# Altium schematic sheet Page1.SchDoc(Page1)
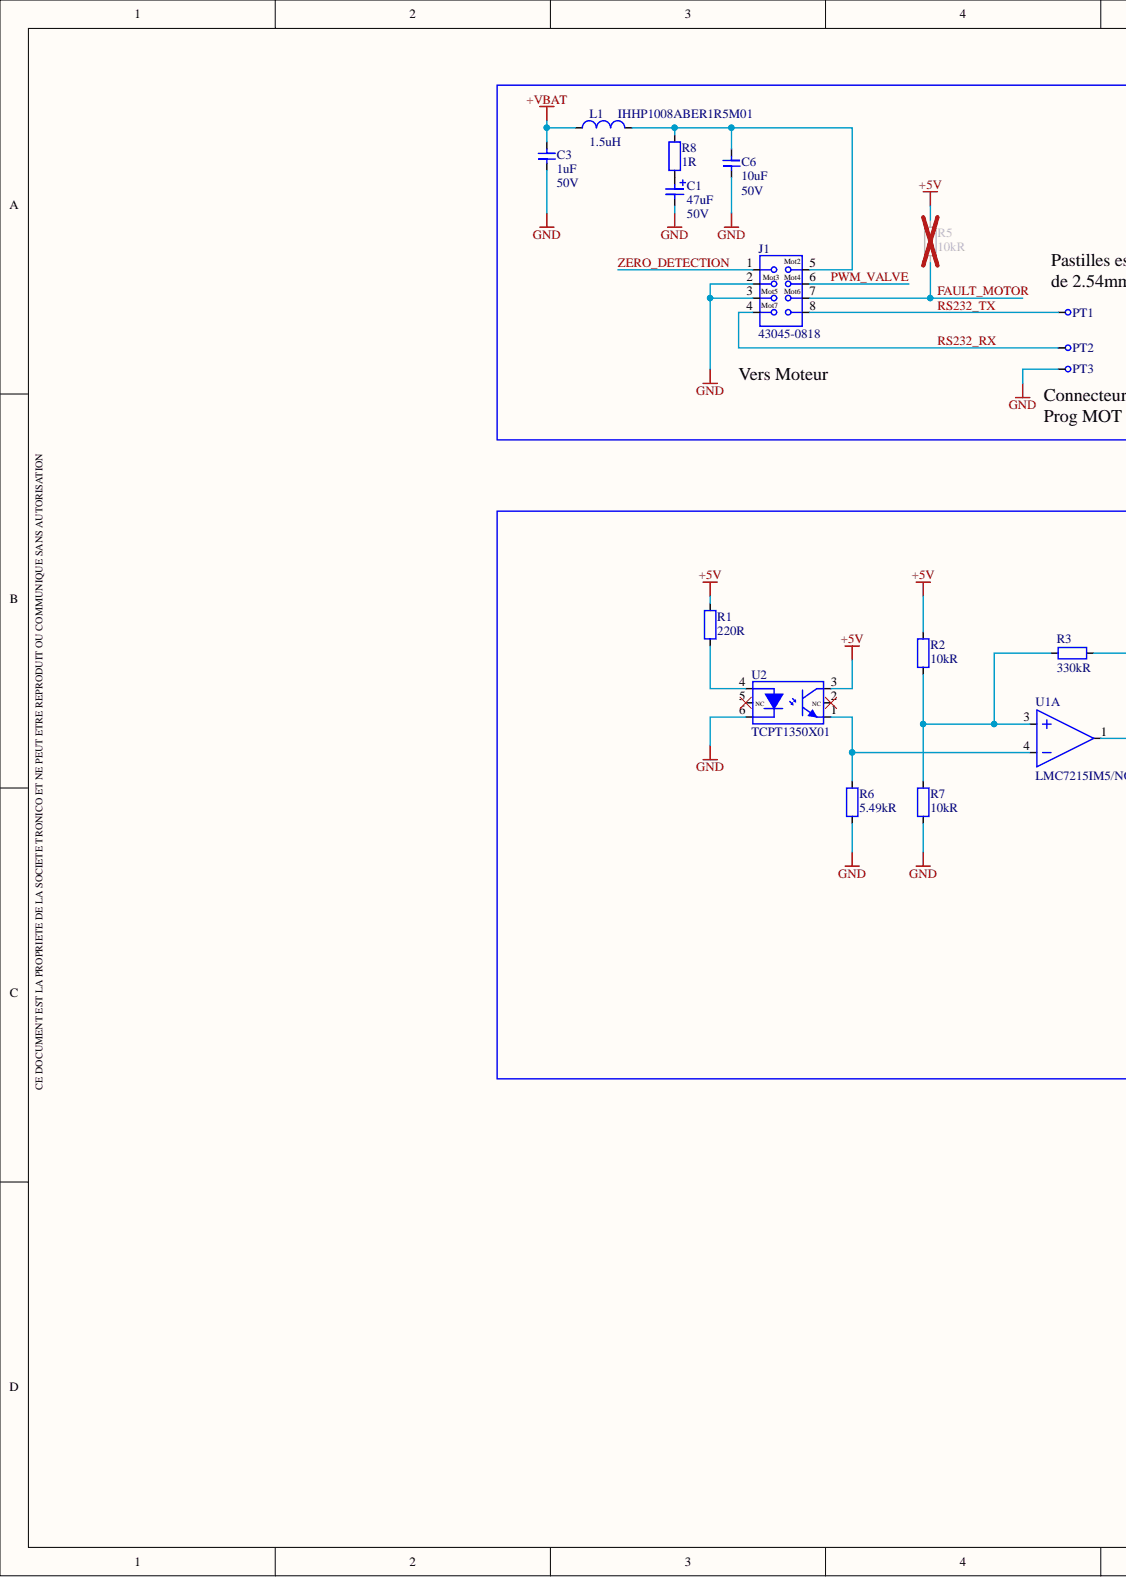
[diagram p0: Page1.SchDoc, left]
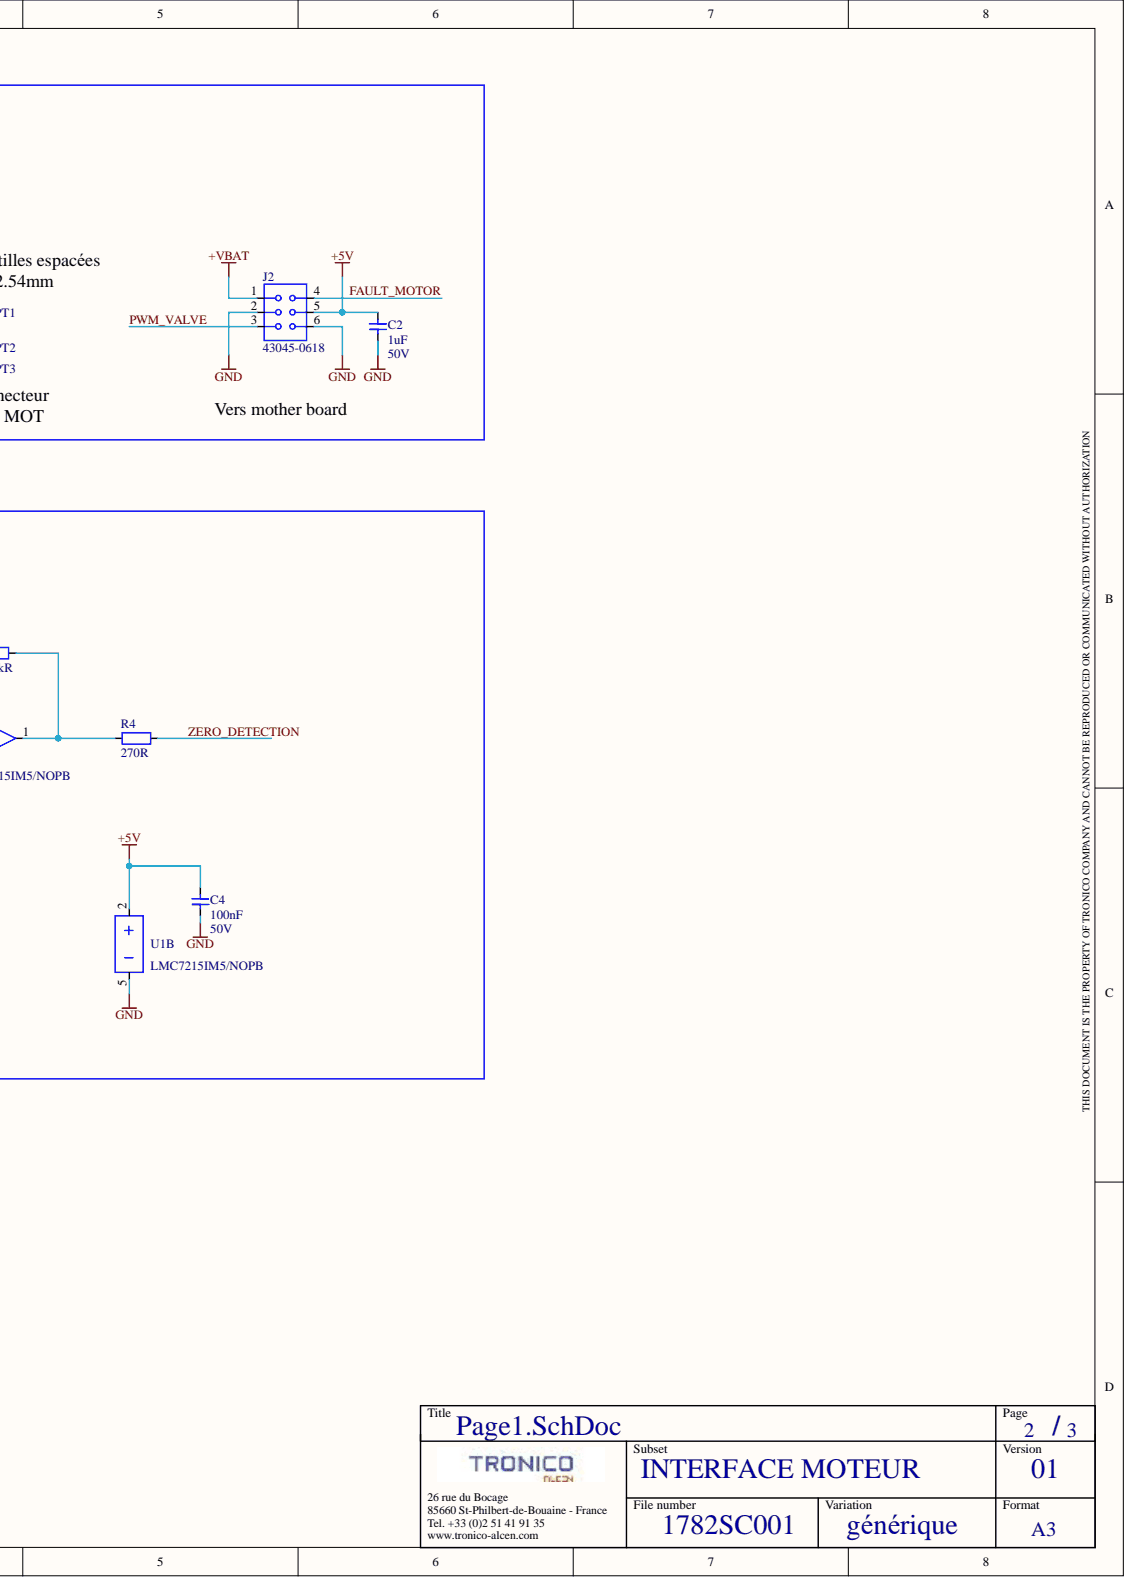
[diagram p1: Page1.SchDoc, right]

## Parts
C1  47uF  Parameter 1: 50V  [CAPE_D_B]
C2  1uF  Parameter 1: 50V  [CAPC_0603X080_T]
C3  1uF  Parameter 1: 50V  [CAPC_0603X080_T]
C4  100nF  Parameter 1: 50V  [CAPC_0603X094_T]
C6  10uF  Parameter 1: 50V  [CAPC_1206X160_T]
J1  43045-0818  [CNT_MOLEX_43045-0818_B]
J2  43045-0618  [CNT_MOLEX_43045-0618_B]
L1  IHHP1008ABER1R5M01  CHAMP5: 1.5uH  [IND_1008X120_B]
PT1  PTC3  [BP_0.8]  (value not drawn)  (no BOM)
PT2  PTC3  [BP_0.8]  (value not drawn)  (no BOM)
PT3  PTC3  [BP_0.8]  (value not drawn)  (no BOM)
R1  220R  [RESC_0603X045_T]
R2  10kR  [RESC_0603X045_T]
R3  330kR  [RESC_0603X045_T]
R4  270R  [RESC_0805X045_T]
R5  10kR  [RESC_0603X045_T]
R6  5.49kR  [RESC_0603X045_T]
R7  10kR  [RESC_0603X045_T]
R8  1R  [RESC_2512X060_T]
U1  LMC7215IM5/NOPB  [SOT23-5_SOT25_TI]  (parts A,B)
U2  TCPT1350X01  [TCPT1350X01]

## Nets
+5V: C2.2 C4.2 J2.5 R1.1 R2.1 R5.1 U1.2 U2.3
+VBAT: C3.2 J2.1 L1.1
GND: C1.2 C2.1 C3.1 C4.1 C6.1 J1.2 J1.3 J2.2 J2.6 PT3.1 R6.2 R7.2 U1.5 U2.6
FAULT_MOTOR: J1.7 J2.4 R5.2
PWM_VALVE: J1.6 J2.3
RS232_RX: J1.4 PT2.1
RS232_TX: J1.8 PT1.1
ZERO_DETECTION: J1.1 R4.1
NetC1_1: C1.1 R8.2
NetC6_2: C6.2 J1.5 L1.2 R8.1
NetR1_2: R1.2 U2.4
NetR2_2: R2.2 R3.2 R7.1 U1.3
NetR3_1: R3.1 R4.2 U1.1
NetR6_1: R6.1 U1.4 U2.1

Not connected: U2 2(NC) 5(NC)

## Library data of the parts
C1 = 21U00041: COND_ALUM CMS D:6.3mm 47uF 50V 20% 680mR; REV_NOTE: Migration vers t_bt; REV_AUTHOR: lclavier; REF_FAB: EEEFT1H470AP; QUALIF: None; LEVEL: 1; FAMILLE: CAPACITIFS; FAB: PANASONIC; Database Table Name: v_bt_all; CHAMP9: -55°C/+105°C; SS_FAMILLE: COND_ALUM; Simulation: CAP; Signal Integrity: Cap Pol; REV_DATE: 14/04/2020 16:15:27; ID_RnD: 21U00041; HelpURL: http://bt_rnd/ds/02_capacitif/Panasonic/EEEFT1H470AP.pdf; ComponentLink1URL: https://industrial.panasonic.com/ww/products/capacitors/aluminum-capacitors/aluminum-cap-smd/ft-v-high-temp-reflow/EEEFT1H470AP; Component Kind: Standard; CHAMP8: 680mR; CHAMP7: 20%; CHAMP6: 50V; CHAMP5: 47uF; CHAMP3: D:6.3mm; CHAMP1: CMS; ACTIF: ACTIF; Library Name: SVNDatabase_BaseTechnique.SVNDbLib
C2 C3 = 23U00347: COND_CERAM CMS 0603 X5R 1uF 50V 10%; REV_NOTE: Maj Champs Techniques; REV_AUTHOR: nlelievre; REF_FAB: CGA3E3X5R1H105K080AB; LEVEL: 1; FAMILLE: CAPACITIFS; FAB: TDK; Database Table Name: v_bt_all; CHAMP9: -55°C/+85°C; CHAMP2: 0603; SS_FAMILLE: COND_CERAM; Simulation: CAP; Signal Integrity: Cap; REV_DATE: 16/10/2019 13:35:17; Library Name: SVNDatabase_BaseTechnique.SVNDbLib; ID_RnD: 23U00347; HelpURL: http://bt_rnd/ds/02_capacitif/TDK/mlccspec_automotive_general_midvoltage_en.pdf; ComponentLink2Description: Manufacturer Website; ComponentLink1Description: Manufacturer Datasheet; Component Kind: Standard; CHAMP7: 10%; CHAMP6: 50V; CHAMP5: 1uF; CHAMP3: X5R; CHAMP1: CMS; ACTIF: ACTIF
C4 = 23U00036: COND_CERAM CMS 0603 X7R 100nF 50V 10%; REV_NOTE: Maj Champs Techniques; REV_AUTHOR: nlelievre; REF_FAB: 06035C104KAT2A; LEVEL: 1; FAMILLE: CAPACITIFS; FAB: AVX; Database Table Name: v_bt_all; CHAMP9: -55°C/+125°C; CHAMP2: 0603; SS_FAMILLE: COND_CERAM; Simulation: CAP; Signal Integrity: Cap; REV_DATE: 16/10/2019 13:20:57; Library Name: SVNDatabase_BaseTechnique.SVNDbLib; ID_RnD: 23U00036; HelpURL: http://Bt_rnd/ds/02_capacitif/AVX/AVX_cx7r.pdf; ComponentLink2Description: Manufacturer Website; ComponentLink1Description: Manufacturer Datasheet; Component Kind: Standard; CHAMP7: 10%; CHAMP6: 50V; CHAMP5: 100nF; CHAMP3: X7R; CHAMP1: CMS; ACTIF: ACTIF
C6 = 23U00488: COND_CERAM CMS 1206 X5R 10uF 50V 10%; REV_NOTE: Migration vers t_bt; REV_AUTHOR: lclavier; REF_FAB: GRM31CR61H106KA12L; LEVEL: 1; FAMILLE: CAPACITIFS; FAB: MURATA; Database Table Name: v_bt_all; CHAMP9: -55°C/+85°C; CHAMP2: 1206; SS_FAMILLE: COND_CERAM; Simulation: CAP; Signal Integrity: Cap; REV_DATE: 14/04/2020 16:23:14; ID_RnD: 23U00488; HelpURL: http://bt_rnd/ds/02_capacitif/murata/GRM31CR61H106KA12L.pdf; ComponentLink1URL: https://www.murata.com/en-us/products/productdetail?partno=GRM31CR61H106KA12%23; Component Kind: Standard; CHAMP7: 10%; CHAMP6: 50V; CHAMP5: 10uF; CHAMP3: X5R; CHAMP1: CMS; ACTIF: ACTIF; Library Name: SVNDatabase_BaseTechnique.SVNDbLib
J1 = A7U00233: CONNECT MICRO-FIT CMS 2x4PTS EMBASE RECT MALE DROIT; REV_NOTE: MaJ Champs Techniques; REV_AUTHOR: llannuzel; LEVEL: 1; FAMILLE: CONNECTIQUE; FAB: MOLEX; Database Table Name: v_bt_all; CHAMP9: -40°C/+105°C; SS_FAMILLE: CONNECT; REV_DATE: 21/06/2019 09:53:44; REF_FAB: 43045-0818; Library Name: SVNDatabase_BaseTechnique.SVNDbLib; ID_RnD: A7U00233; HelpURL: http://bt_rnd/ds/10_connectique/MOLEX/43045_Series.pdf; ComponentLink2URL: https://www.molex.com/molex/products/datasheet.jsp?part=active/0430450818_PCB_HEADERS.xml; ComponentLink2Description: Manufacturer Website; ComponentLink1URL: https://www.molex.com/pdm_docs/sd/430450818_sd.pdf; ComponentLink1Description: Manufacturer Datasheet; Component Kind: Standard; CHAMP8: DROIT; CHAMP7: MALE; CHAMP6: RECT; CHAMP5: EMBASE; CHAMP4: 2x4PTS; CHAMP3: CMS; CHAMP1: MICRO-FIT; ACTIF: ACTIF
J2 = A7U00234: CONNECT MICRO-FIT CMS 2x3PTS EMBASE RECT MALE DROIT; REV_NOTE: MaJ Champs Techniques; REV_AUTHOR: llannuzel; LEVEL: 1; FAMILLE: CONNECTIQUE; FAB: MOLEX; Database Table Name: v_bt_all; CHAMP9: -40°C/+105°C; SS_FAMILLE: CONNECT; REV_DATE: 21/06/2019 09:53:44; REF_FAB: 43045-0618; Library Name: SVNDatabase_BaseTechnique.SVNDbLib; ID_RnD: A7U00234; HelpURL: http://bt_rnd/ds/10_connectique/MOLEX/43045_Series.pdf; ComponentLink2URL: https://www.molex.com/pdm_docs/sd/430450618_sd.pdf; ComponentLink2Description: Manufacturer Website; ComponentLink1URL: https://www.molex.com/molex/products/datasheet.jsp?part=active/0430450618_PCB_HEADERS.xml; ComponentLink1Description: Manufacturer Datasheet; Component Kind: Standard; CHAMP8: DROIT; CHAMP7: MALE; CHAMP6: RECT; CHAMP5: EMBASE; CHAMP4: 2x3PTS; CHAMP3: CMS; CHAMP1: MICRO-FIT; ACTIF: ACTIF
L1 = 42U00104: SELF CMS 2.5x2mm  1.5uH 20% 3.1A; REV_NOTE: Maj Footprint Ref & Footprint Path; REV_AUTHOR: jcaille; LEVEL: 1; FAMILLE: INDUCTIFS; FAB: VISHAY; Database Table Name: v_bt_all; CHAMP9: -55°C/+125°C; SS_FAMILLE: SELF; Simulation: INDUCTOR; Signal Integrity: Inductor; REV_DATE: 10/02/2020 15:29:38; REF_FAB: IHHP1008ABER1R5M01; ID_RnD: 42U00104; HelpURL: http://bt_rnd/ds/04_inductif/vishay/IHHP_1008_AB_01.pdf; ComponentLink2Description: Manufacturer Website; ComponentLink1Description: Manufacturer Datasheet; Component Kind: Standard; CHAMP7: 3.1A; CHAMP6: 20%; CHAMP2: 2.5x2mm; CHAMP1: CMS; ACTIF: ACTIF; Library Name: SVNDatabase_BaseTechnique.SVNDbLib
PT1-PT3 = H6U00003: POINT_TEST; REV_NOTE: Migration vers t_bt; REV_AUTHOR: jrenvoise; LEVEL: 1; FAMILLE: DIVERS; FAB: TRONICO; Database Table Name: v_bt_all; SS_FAMILLE: POINT_TEST; REV_DATE: 24/04/2017 12:22:28; REF_FAB: PTC3; ID_RnD: H6U00003; ACTIF: ACTIF; Library Name: SVNDatabase_BaseTechnique.SVNDbLib
R1 = 11U00106: REST CMS 0603 100PPM  220R 1/10W 1%  -55°C/+155°C; Valide / Non Valide: OK; REV_NOTE: MaJ Champs Techniques; REV_AUTHOR: llannuzel; REF_FAB: RC0603FR-07220RL; LEVEL: 1; FAMILLE: RESISTIFS; FAB: YAGEO; Database Table Name: v_bt_all; CHAMP2: 0603; SS_FAMILLE: REST; Simulation: RESISTOR; Signal Integrity: Res; REV_DATE: 11/02/2019 09:50:59; Library Name: SVNDatabase_BaseTechnique.SVNDbLib; ID_RnD: 11U00106; HelpURL: http://bt_rnd/ds/01_resistif/yageo/RC-L_Series.pdf; ComponentLink2Description: Manufacturer Website; ComponentLink1Description: Manufacturer Datasheet; Component Kind: Standard; CHAMP9: -55°C/+155°C; CHAMP7: 1%; CHAMP6: 1/10W; CHAMP5: 220R; CHAMP3: 100PPM; CHAMP1: CMS; ACTIF: ACTIF
R2 R5 R7 = 11U00108: REST CMS 0603 100PPM 10kR 1/10W 1% -55°C/+155°C; Valide / Non Valide: OK; REV_NOTE: MaJ description; REV_AUTHOR: jrenvoise; REF_FAB: RC0603FR-0710KL; LEVEL: 1; FAMILLE: RESISTIFS; FAB: YAGEO; Database Table Name: v_bt_all; CHAMP2: 0603; SS_FAMILLE: REST; Simulation: RESISTOR; Signal Integrity: Res; REV_DATE: 12/09/2018 10:54:26; ID_RnD: 11U00108; HelpURL: http://bt_rnd/ds/01_resistif/yageo/RC-L_Series.pdf; ComponentLink2Description: Manufacturer Website; ComponentLink1Description: Manufacturer Datasheet; Component Kind: Standard; CHAMP9: -55°C/+155°C; CHAMP7: 1%; CHAMP6: 1/10W; CHAMP5: 10kR; CHAMP3: 100PPM; CHAMP1: CMS; ACTIF: ACTIF; Library Name: SVNDatabase_BaseTechnique.SVNDbLib
R3 = 11U00053: REST CMS 0603 100PPM 330kR 1/10W 1% -55°C/+155°C; Valide / Non Valide: OK; REV_NOTE: MaJ lien vers datasheet; REV_AUTHOR: jrenvoise; REF_FAB: CRCW0603330KFKEA; LEVEL: 1; FAMILLE: RESISTIFS; FAB: VISHAY; Database Table Name: v_bt_all; CHAMP2: 0603; SS_FAMILLE: REST; Simulation: RESISTOR; Signal Integrity: Res; REV_DATE: 10/09/2018 16:59:01; ID_RnD: 11U00053; HelpURL: http://bt_rnd/ds/01_resistif/vishay/Vishay_D-CRCWE3.pdf; ComponentLink2Description: Manufacturer Website; ComponentLink1Description: Manufacturer Datasheet; Component Kind: Standard; CHAMP9: -55°C/+155°C; CHAMP7: 1%; CHAMP6: 1/10W; CHAMP5: 330kR; CHAMP3: 100PPM; CHAMP1: CMS; ACTIF: ACTIF; Library Name: SVNDatabase_BaseTechnique.SVNDbLib
R4 = 11U00279: REST CMS 0805 100PPM 270R 1/8W 1%  -55°C/+155°C; Valide / Non Valide: OK; REV_NOTE: MaJ description; REV_AUTHOR: jrenvoise; REF_FAB: RC0805FR-07270RL; LEVEL: 1; FAMILLE: RESISTIFS; FAB: YAGEO; Database Table Name: v_bt_all; CHAMP2: 0805; SS_FAMILLE: REST; Simulation: RESISTOR; Signal Integrity: Res; REV_DATE: 12/09/2018 11:21:20; ID_RnD: 11U00279; HelpURL: http://bt_rnd/ds/01_resistif/yageo/RC-L_Series.pdf; ComponentLink2Description: Manufacturer Website; ComponentLink1Description: Manufacturer Datasheet; Component Kind: Standard; CHAMP9: -55°C/+155°C; CHAMP7: 1%; CHAMP6: 1/8W; CHAMP5: 270R; CHAMP3: 100PPM; CHAMP1: CMS; ACTIF: ACTIF; Library Name: SVNDatabase_BaseTechnique.SVNDbLib
R6 = 11U00688: REST CMS 0603 100PPM 5.49kR 1/10W 1% -55°C/+155°C; Valide / Non Valide: OK; REV_NOTE: MaJ description; REV_AUTHOR: jrenvoise; REF_FAB: RC0603FR-075K49L; LEVEL: 1; FAMILLE: RESISTIFS; FAB: YAGEO; Database Table Name: v_bt_all; CHAMP2: 0603; SS_FAMILLE: REST; Simulation: RESISTOR; Signal Integrity: Res; REV_DATE: 12/09/2018 11:17:00; ID_RnD: 11U00688; HelpURL: http://bt_rnd/ds/01_resistif/yageo/RC-L_Series.pdf; ComponentLink2Description: Manufacturer Website; ComponentLink1Description: Manufacturer Datasheet; Component Kind: Standard; CHAMP9: -55°C/+155°C; CHAMP7: 1%; CHAMP6: 1/10W; CHAMP5: 5.49kR; CHAMP3: 100PPM; CHAMP1: CMS; ACTIF: ACTIF; Library Name: SVNDatabase_BaseTechnique.SVNDbLib
R8 = 11U01009: REST CMS 2512 100PPM 1R 1.5W 1% 500V; Valide / Non Valide: OK; REV_NOTE: MaJ Champs URL; REV_AUTHOR: lclavier; REF_FAB: CRCW25121R00FKEGHP; QUALIF: None; LEVEL: 1; FAMILLE: RESISTIFS; FAB: VISHAY; Database Table Name: v_bt_all; CHAMP9: -55°C/+155°C; CHAMP2: 2512; SS_FAMILLE: REST; Simulation: RESISTOR; Signal Integrity: Res; REV_DATE: 16/04/2020 16:46:14; ID_RnD: 11U01009; HelpURL: http://bt_rnd/ds/01_resistif/Vishay/CRCW25121R00FKEGHP.pdf; ComponentLink1URL: https://octopart.com/crcw25121r00fkeghp-vishay-44382594?r=sp; Component Kind: Standard; CHAMP8: 500V; CHAMP7: 1%; CHAMP6: 1.5W; CHAMP5: 1R; CHAMP3: 100PPM; CHAMP1: CMS; ACTIF: ACTIF; Library Name: SVNDatabase_BaseTechnique.SVNDbLib
U1 = 61U00045: CI_AOP_COMPAR CMS SOT23-5  Single COMP +2.7V/+5V; REV_NOTE: MaJ Champs Techniques; REV_AUTHOR: llannuzel; LEVEL: 1; FAMILLE: CIRCUITS_INTEGRES; FAB: TEXAS INSTRUMENTS; Database Table Name: v_bt_all; CHAMP10: -65°C/+150°C; CHAMP9: -40°C/+85°C; CHAMP8: R TO R; SS_FAMILLE: CI_AOP_COMPAR; REV_DATE: 09/05/2019 10:36:41; REF_FAB: LMC7215IM5/NOPB; Library Name: SVNDatabase_BaseTechnique.SVNDbLib; ID_RnD: 61U00045; HelpURL: http://bt_rnd/ds/06_ci/TI/LMC7215_LMC7225.pdf; ComponentLink2Description: Manufacturer Website; ComponentLink1Description: Manufacturer Datasheet; Component Kind: Standard; CHAMP7: +2.7V/+5V; CHAMP6: COMP; CHAMP5: SINGLE; CHAMP2: SOT23-5; CHAMP1: CMS; ACTIF: ACTIF
U2 = ECU00004: CAPTEUR_OPTO CMS 5.5x4x4 PHOTOTRANSISTOR +1.0V/+1.4V NPN; Valide: OK; REV_NOTE: MaJ Champs Techniques; REV_AUTHOR: lclavier; LEVEL: 1; FAMILLE: OPTOELECTRONIQUE; FAB: VISHAY; Database Table Name: v_bt_all; CHAMP10: -40°C/+125°C; SS_FAMILLE: CAPTEUR_OPTO; REV_DATE: 23/04/2020 09:14:53; REF_FAB: TCPT1350X01; Library Name: SVNDatabase_BaseTechnique.SVNDbLib; ID_RnD: ECU00004; HelpURL: http://bt_rnd/ds/15_opto/VISHAY/tcpt1350x01.pdf; ComponentLink1URL: https://www.vishay.com/docs/84815/tcpt1350x01.pdf; Component Kind: Standard; CHAMP9: -40°C/+125°C; CHAMP6: NPN; CHAMP5: +1.0V/+1.4V; CHAMP3: PHOTOTRANSISTOR; CHAMP2: 5.5x4x4; CHAMP1: CMS; ACTIF: ACTIF
